# Altium schematic sheet Sensors.SchDoc
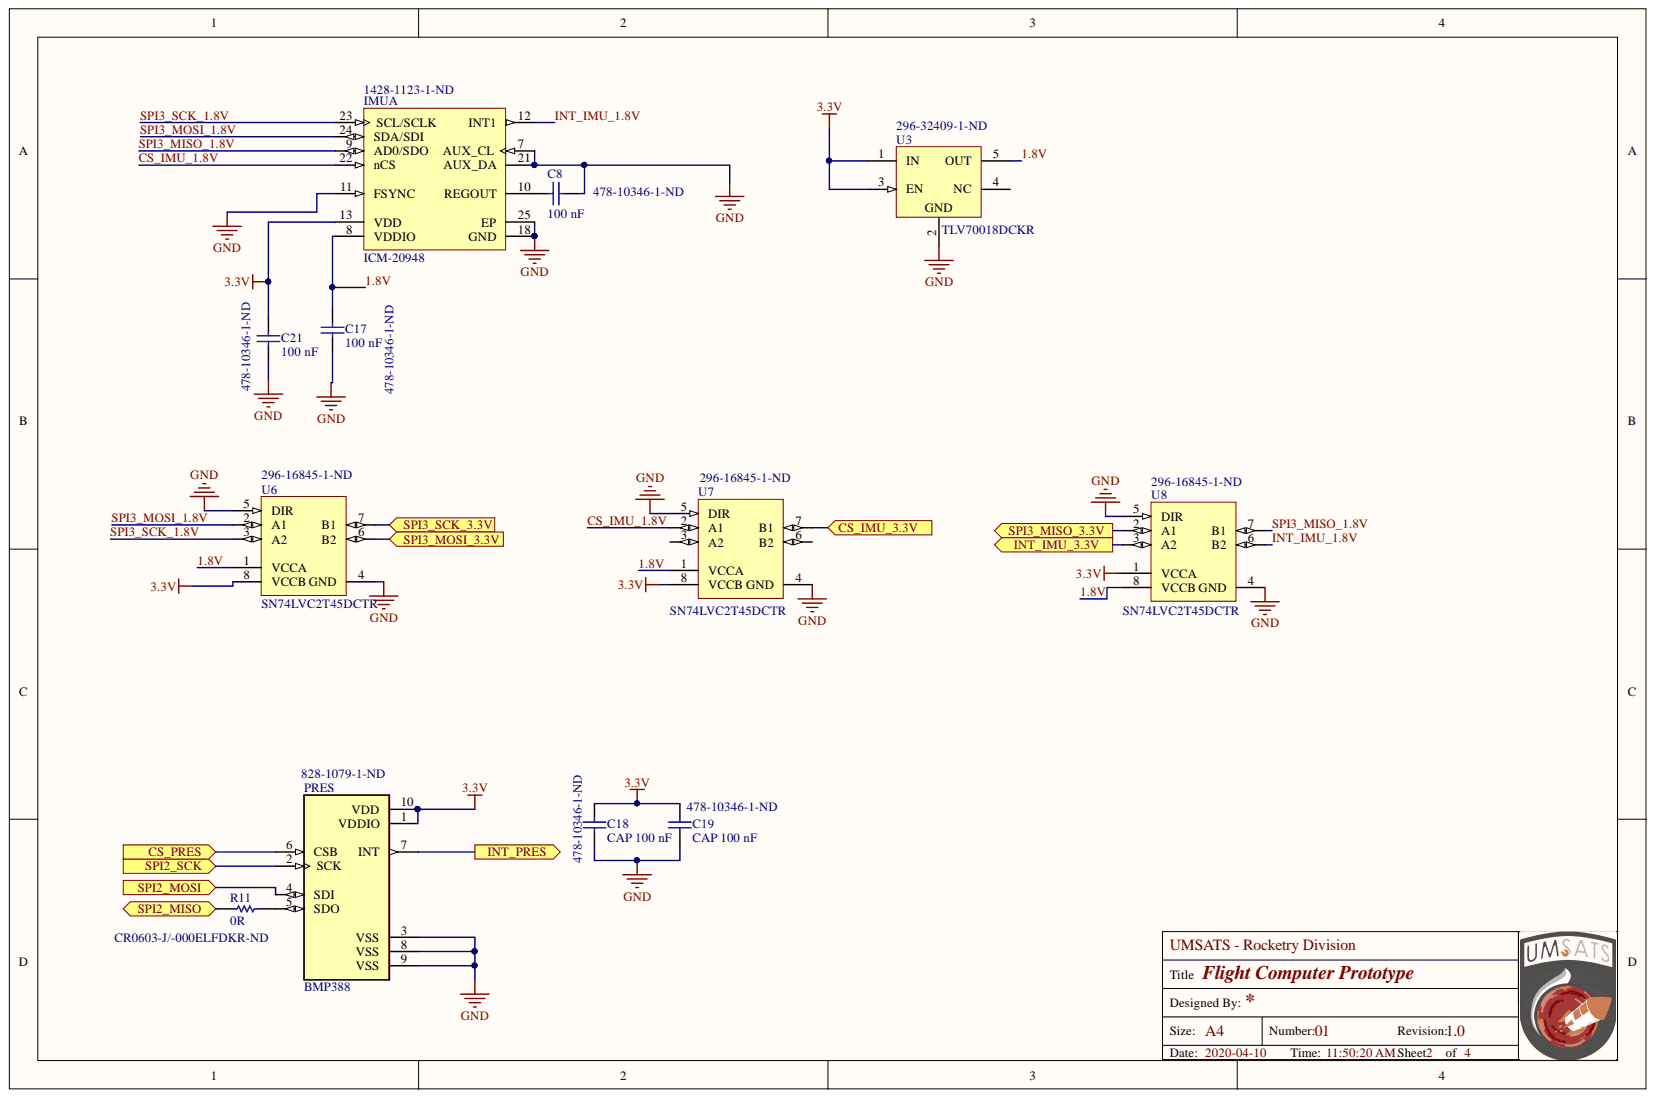
[diagram p0: Sensors.SchDoc]

## Parts
C8  100 nF  Supplier Part Number 1: 478-10346-1-ND  [CAPC1608X90X35ML15T15]
C17  100 nF  [CAPC1608X90X35ML15T15]
C18  CAP 100 nF  Supplier Part Number 1: 478-10346-1-ND  [06035C104KAT4A]
C19  CAP 100 nF  Supplier Part Number 1: 478-10346-1-ND  [06035C104KAT4A]
C21  100 nF  Supplier Part Number 1: 478-10346-1-ND  [CAPC1608X90X35ML15T15]
IMU  ICM-20948  Supplier Part Number 1: 1428-1123-1-ND  [TDK-QFN-24_L]  (part A)
PRES  BMP388  Supplier Part Number 1: 828-1079-1-ND  [*PQFN50P200X200X80-10N]
R11  0R  [CR0603-J/-000ELF]
U3  TLV70018DCKR  Supplier Part Number 1: 296-32409-1-ND  [DCK0005A_N]
U6  SN74LVC2T45DCTR  Supplier Part Number 1: 296-16845-1-ND  [DCU0008A_N]
U7  SN74LVC2T45DCTR  Supplier Part Number 1: 296-16845-1-ND  [DCU0008A_N]
U8  SN74LVC2T45DCTR  Supplier Part Number 1: 296-16845-1-ND  [DCU0008A_N]

## Ports
CS_IMU_3.3V, CS_PRES, INT_IMU_3.3V, INT_PRES, SPI2_MISO, SPI2_MOSI, SPI2_SCK, SPI3_MISO_3.3V, SPI3_MOSI_3.3V, SPI3_SCK_3.3V

## Nets
3.3V: C18.1 C19.1 C21.2 IMU.13(VDD) PRES.1(VDDIO) PRES.10(VDD) U3.1(IN) U3.3(EN) U6.8(VCCB) U7.8(VCCB) U8.1(VCCA)
GND: C8.2 C17.1 C18.2 C19.2 C21.1 IMU.7(AUX_CL) IMU.11(FSYNC) IMU.18(GND) IMU.21(AUX_DA) IMU.25(EP) PRES.3(VSS) PRES.8(VSS) PRES.9(VSS) U3.2 U6.4(GND) U6.5(DIR) U7.4(GND) U7.5(DIR) U8.4(GND) U8.5(DIR)
1.8V: C17.2 IMU.8(VDDIO) U3.5(OUT) U6.1(VCCA) U7.1(VCCA) U8.8(VCCB)
CS_IMU_1.8V: IMU.22(nCS) U7.2(A1)
CS_IMU_3.3V: U7.7(B1) ; port CS_IMU_3.3V
CS_PRES: PRES.6(CSB) ; port CS_PRES
INT_IMU_1.8V: IMU.12(INT1) U8.6(B2)
INT_IMU_3.3V: U8.3(A2) ; port INT_IMU_3.3V
INT_PRES: PRES.7(INT) ; port INT_PRES
SPI2_MISO: R11.1 ; port SPI2_MISO
SPI2_MOSI: PRES.4(SDI) ; port SPI2_MOSI
SPI2_SCK: PRES.2(SCK) ; port SPI2_SCK
SPI3_MISO_1.8V: IMU.9(AD0/SDO) U8.7(B1)
SPI3_MISO_3.3V: U8.2(A1) ; port SPI3_MISO_3.3V
SPI3_MOSI_1.8V: IMU.24(SDA/SDI) U6.2(A1)
SPI3_MOSI_3.3V: U6.6(B2) ; port SPI3_MOSI_3.3V
SPI3_SCK_1.8V: IMU.23(SCL/SCLK) U6.3(A2)
SPI3_SCK_3.3V: U6.7(B1) ; port SPI3_SCK_3.3V
NetC8_1: C8.1 IMU.10(REGOUT)
NetPRES_5: PRES.5(SDO) R11.2

Not connected: U3 4(NC); U7 3(A2) 6(B2)

## Library data of the parts
C8 C17 C21 = CMP-2006-04388-1: Manufacturer: AVX Interconnect / Elco; Part Number: 06035C104K4Z2A; Component Kind: Standard; Library Name: Altium Content Vault; Supplier 1: Digi-Key
  C17: Supplier Part Number 1: 478-10346-1-ND
C18 C19 = Cap: Capacitor; Component Kind: Standard; LatestRevisionDate: 17-Jul-2002; LatestRevisionNote: Re-released for DXP Platform.; Library Name: Miscellaneous Devices.IntLib; Published: 8-Jun-2000; Signal Integrity: Cap; Simulation: CAP; Supplier 1: Digi-Key; Publisher: Altium Limited
IMU = CMP-2000-07532-1: World’s Lowest Power 9-Axis MEMS MotionTracking(TM) Device, 1.71 to 3.6 V, -40 to 85 degC, 24-Pin QFN, RoHS, Tape and Reel; Manufacturer: TDK; Component Kind: Standard; Datasheet URL: https://www.invensense.com/wp-content/uploads/2016/06/DS-000189-ICM-20948-v1.3.pdf; Datasheet Version: Rev. 1.3, 06/2017; Library Name: Altium Content Vault; Manufacturer URL: http://www.tdk.com/; Mounting Technology: SurfaceMount; Package Description: 24-Pin Quad Flat No-lead Package, Body 3 x 3 mm, Pitch 0.4 mm; Package Reference: QFN-24; Supplier 1: Digi-Key
PRES = BMP388: P-Sensor SPI I2C 1, 25 Bar SMD; MF: Bosch Sensortec; Availability: Unavailable; Component Kind: Standard; Library Name: BMP388.SchLib; MP: BMP388; Package: WFLGA-10 Bosch Tools; Price: None; SnapEDA_Link: https://www.snapeda.com/parts/BMP388/Bosch%20Sensortec/view-part/?ref=snap; Supplier 1: Digi-Key
R11 = Res1: Resistor; Value: 1K; Component Kind: Standard; LatestRevisionDate: 17-Jul-2002; LatestRevisionNote: Re-released for DXP Platform.; Library Name: Miscellaneous Devices.IntLib; PackageDescription: Axial Device, Thru-Hole; 2 Leads; 0.3 in Pin Spacing; PackageReference: AXIAL-0.3; Published: 8-Jun-2000; Signal Integrity: Res; Simulation: RESISTOR; Supplier 1: Digi-Key; Supplier Part Number 1: CR0603-J/-000ELFDKR-ND; Publisher: Altium Limited
U3 = CMP-0394-00928-2: Single Output LDO, 200 mA, Fixed 1.8 V Output, 2 to 5.5 V Input, with Low IQ, 5-pin SC70 (DCK), -40 to 125 degC, Green (RoHS & no Sb/Br); Manufacturer: Texas Instruments; Accuracy(%): 2; Fixed Output Options(V): 1.8; Iout(Max)(A): 0.2; Iq(Typ)(mA): 0.03; SubFamily: Single Channel LDO; Vdo(Typ)(mV): 175; Vin(Max)(V): 5.5; Code_JEDEC: MO-203; Component Kind: Standard; ComponentLink1Description: Manufacturer URL; ComponentLink1URL: http://www.ti.com/; ComponentLink2Description: Datasheet; ComponentLink2URL: http://www.ti.com/general/docs/lit/getliterature.tsp?genericPartNumber=TLV70018&fileType=pdf; ComponentLink3Description: Package Specification; ComponentLink3URL: http://www.ti.com/litv/pdf/mpds025f; DatasheetVersion: SLVSA00D; Eco-Plan: Green (RoHS & no Sb/Br); Library Name: Altium Content Vault; Mounting Technology: Surface Mount; PackageDescription: 5-Pin Plastic Small-Outline, Body 2 x 1.25 mm, Pitch 0.65 mm; PackageReference: DCK0005A; PackageVersion: 4093553-3/G; PartNumber: TLV70018DCKR; Supplier 1: Digi-Key; Vin(Min)(V): 2; Vout Adj(Max)(V): 1.8; Vout Adj(Min)(V): 1.8
U6-U8 = CMP-0859-00011-4: Dual-Bit Dual Supply Transceiver with Configurable Voltage Translation and 3-State Outputs, DCU0008A, LARGE T&R; Manufacturer: Texas Instruments; 3-State Output: Yes; Bits(#): 2; F @ Nom Voltage(Max)(Mhz): 150; ICC @ Nom Voltage(Max)(mA): 0.004; Input Type: CMOS/TTL; Logic: True; Output Drive (IOL/IOH)(Max)(mA): 32/-32; Output Type: CMOS; Rating: Military; Schmitt Trigger: No; Sub-Family: Dual Supply Translator; Technology Family: LVC; tpd @ Nom Voltage(Max)(ns): 17.7,10.3,8.3,7.2; VCC(Max)(V): 5.5; VCC(Min)(V): 1.65; Voltage(Nom)(V): 1.8,2.5,3.3,5; Component Kind: Standard; DatasheetVersion: SCES516J; Generic Part Number: SN74LVC2T45; Library Name: Altium Content Vault; Operating Temperature Range(C): -40 to 85; PackageReference: DCU0008A; Supplier 1: Digi-Key
